# Altium schematic sheet Microcontroller.SchDoc
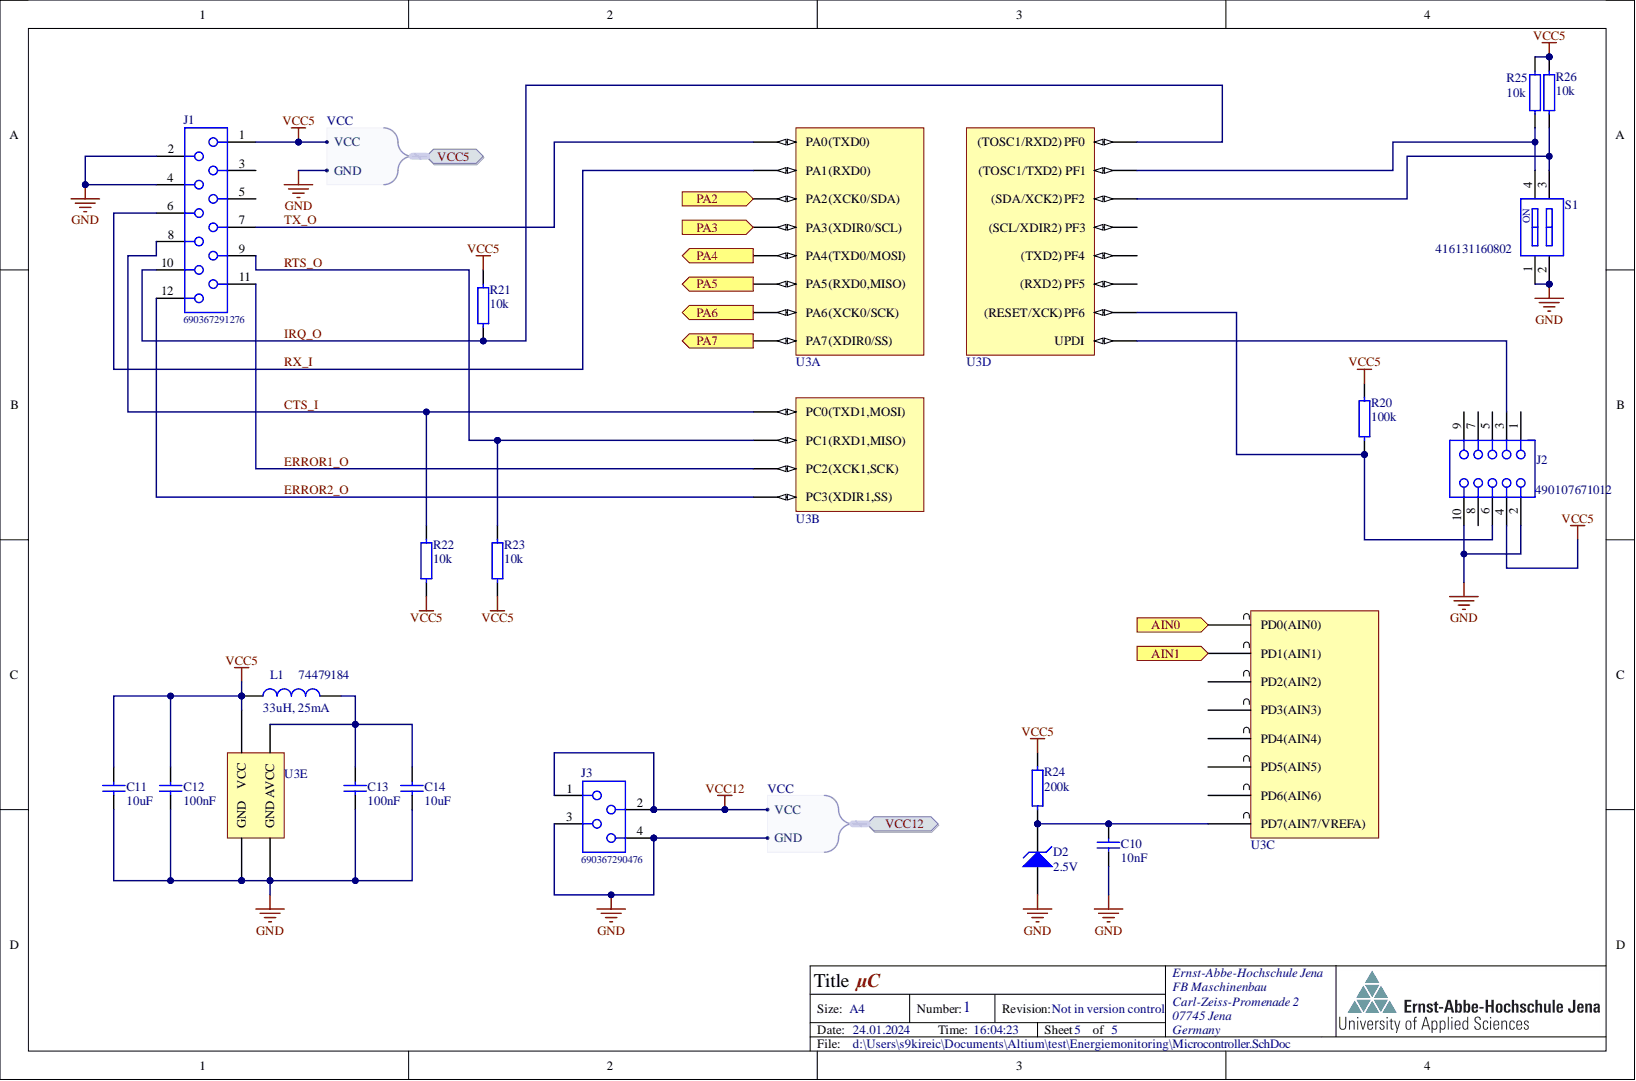
[diagram p0: Microcontroller.SchDoc]

## Parts
C10  10nF  [SMD-0805]
C11  10uF  [SMD-0805]
C12  100nF  [SMD-0805]
C13  100nF  [SMD-0805]
C14  10uF  [SMD-0805]
D2  value 2.5V  [TO92-3]  (hidden comment: Micropower Voltage Reference Diode)
J1  690367291276  [690367291276]
J2  490107671012  [490107671012_Permanent Application]
J3  690367290476  [690367290476]
L1  33uH, 25mA  Rated Current: 25mA  [WE-MI_1206]
R20  100k  [R-SMD-0805]
R21  10k  [R-SMD-0805]
R22  10k  [R-SMD-0805]
R23  10k  [R-SMD-0805]
R24  200k  [R-SMD-0805]
R25  10k  [R-SMD-0805]
R26  10k  [R-SMD-0805]
S1  416131160802  [416131160802]
U3  ATmega808-AUR  [32-Pin TQFP]  (value not drawn)  (parts A,B,C,D,E)

## Ports
AIN0, AIN1, PA2, PA3, PA4, PA5, PA6, PA7, VCC5, VCC5.GND, VCC5.VCC, VCC12, VCC12.GND, VCC12.VCC

## Nets
AIN0: U3.10(PD0_(AIN0)) ; port AIN0
AIN1: U3.11(PD1_(AIN1)) ; port AIN1
CTS_I: J1.8 R22.2 U3.6(PC0_(TXD1,MOSI))
ERROR1_O: J1.11 U3.8(PC2_(XCK1,SCK))
ERROR2_O: J1.12 U3.9(PC3_(XDIR1,SS))
GND: C10.1 C11.2 C12.2 C13.2 C14.2 D2.1 J1.2 J1.4 J2.2 J2.10 J3.3 J3.4 S1.1 S1.2 U3.19(GND) U3.29(GND) ; port VCC12, port VCC5
IRQ_O: J1.10 R21.2 U3.20((TOSC1/RXD2)_PF0)
PA2: U3.32(PA2_(XCK0/SDA)) ; port PA2
PA3: U3.1(PA3_(XDIR0/SCL)) ; port PA3
PA4: U3.2(PA4_(TXD0/MOSI)) ; port PA4
PA5: U3.3(PA5_(RXD0,MISO)) ; port PA5
PA6: U3.4(PA6_(XCK0/SCK)) ; port PA6
PA7: U3.5(PA7_(XDIR0/SS)) ; port PA7
RTS_O: J1.9 R23.2 U3.7(PC1_(RXD1,MISO))
RX_I: J1.6 U3.31(PA1_(RXD0))
TX_O: J1.7 U3.30(PA0_(TXD0))
VCC5: C11.1 C12.1 J1.1 J2.4 L1.1 R20.2 R21.1 R22.1 R23.1 R24.2 R25.1 R26.1 U3.28(VCC) ; port VCC5
VCC12: J3.1 J3.2 ; port VCC12
NetC11_1: C13.1 C14.1 L1.2 U3.18(AVCC)
NetC13_2: C10.2 D2.2 R24.1 U3.17(PD7_(AIN7/VREFA))
NetJ1_3: J1.3
NetJ1_5: J1.5
NetJ2_1: J2.1
NetJ2_3: J2.3 U3.27(UPDI)
NetJ2_5: J2.5
NetJ2_6: J2.6 R20.1 U3.26((RESET/XCK)_PF6)
NetJ2_7: J2.7
NetJ2_8: J2.8
NetJ2_9: J2.9
NetR30_2: R25.2 S1.4 U3.21((TOSC1/TXD2)_PF1)
NetR31_2: R26.2 S1.3 U3.22((SDA/XCK2)_PF2)
NetU3_12: U3.12(PD2_(AIN2))
NetU3_13: U3.13(PD3_(AIN3))
NetU3_14: U3.14(PD4_(AIN4))
NetU3_15: U3.15(PD5_(AIN5))
NetU3_16: U3.16(PD6_(AIN6))
NetU3_23: U3.23((SCL/XDIR2)_PF3)
NetU3_24: U3.24((TXD2)_PF4)
NetU3_25: U3.25((RXD2)_PF5)

## Library data of the parts
C10-C14 = C: Kondensator; Component Kind: Standard; Library Name: SMD.IntLib
  C10: Value: 10nF
  C11 C14: Value: 10uF
  C12 C13: Value: 100nF
D2 = LM285-2.5-N: • ±20 mV (±0.8%) max. Initial Tolerance (A Grade)  • Operating Current of 20 μA to 20 mA  • 0.6Ω Dynamic Impedance (A Grade)  • Low Temperature Coefficient  • Low Voltage Reference—2.5V  • 1.2V Device and Adjustable Device Also Available—LM185-1.2 Series and LM185 Series, respectively; Component Kind: Standard; ComponentLink1Description: Datasheet; ComponentLink1URL: https://www.ti.com/lit/gpn/lm285-2.5-n; ComponentLink2Description: Link; ComponentLink2URL: https://www.ti.com/product/LM285-2.5-N?keyMatch=LM285-2.5-N&tisearch=search-everything&usecase=GPN-ALT; Library Name: TI-Ref.IntLib; Supplier 1: Digikey; Supplier 2: Mouser; Supplier Part Number 1: LM285BXZ-2.5/NOPB-ND; Supplier Part Number 2: 926-LM285BXZ25NOPB
J1 = 690367291276: WR-MM Mini Module Connector, Female, SMT, with Latch & Polarization, Straight, 12p; Manufacturer: Wurth Elektronik; Contact Resistance: 10mOhm; Insulation Resistance: 1000MOhm; Pin Number: 12; Pitch: 2.54mm; Rated Current: 1.5A; Withstanding Voltage: 500VAC; Working Voltage: 100VAC; Component Kind: Standard; ComponentLink1Description: Manufacturer; ComponentLink1URL: http://www.we-online.de; ComponentLink2Description: Datasheet; ComponentLink2URL: https://www.we-online.com/catalog/datasheet/690367291276.pdf; Gender: Female; Length: 19.84mm; Library Name: 690367291076_Altium_WR-MM_rev20a.IntLib; Manufacturer Part Number: 690367291276; Mounting Technology: SMT; Operating Temperature: -40 to +105degC; Packaging: Tape and Reel; Published Date: May 2020; Type: Straight
J2 = 490107671012: WR-WST REDFIT IDC SKEDD, pitch 2.54mm, 10p; Manufacturer: Wurth Elektronik; Contact Resistance: 10mΩ; Gender: Transition; Rated Current: 1A; Working Voltage: 100VAC; Category: Wire-to-Board Connectors; Component Kind: Standard; ComponentLink1Description: Website Link; ComponentLink1URL: https://www.we-online.com/redexpert/article/490107671012?ad; ComponentLink2Description: Datasheet Link; ComponentLink2URL: https://www.we-online.com/redexpert/spec/490107671012?ad; Library Name: Altium_WR-WST (rev22a).IntLib; Manufacturer Part Number: 490107671012; Match Code: WR-WST; Mount: Press Fit; Number of Pins: 10; Operating Temperature Max: 105°C; Operating Temperature Min: -25°C; Packaging: Tube; Pitch: 2.54mm
J3 = 690367290476: WR-MM Mini Module Connector, Female, SMT, with Latch & Polarization, Straight, 4p; Manufacturer: Wurth Elektronik; Contact Resistance: 10mOhm; Insulation Resistance: 1000MOhm; Pin Number: 4; Pitch: 2.54mm; Rated Current: 1.5A; Withstanding Voltage: 500VAC; Working Voltage: 100VAC; Component Kind: Standard; ComponentLink1Description: Manufacturer; ComponentLink1URL: http://www.we-online.de; ComponentLink2Description: Datasheet; ComponentLink2URL: https://www.we-online.com/catalog/datasheet/690367290476.pdf; Gender: Female; Length: 9.68mm; Library Name: 690367291076_Altium_WR-MM_rev20a.IntLib; Manufacturer Part Number: 690367290476; Mounting Technology: SMT; Operating Temperature: -40 to +105degC; Packaging: Tape and Reel; Published Date: May 2020; Type: Straight
L1 = 74479184: WE-MI SMT Multilayer Inductor, size 1206, 33uH, 25mA; Manufacturer: Wurth Elektronik; DC Resistance max.: 0.9Ohm; DC Resistance typ.: 0.65Ohm; Q-Factor: 35; Component Kind: Standard; ComponentLink1Description: Manufacturer; ComponentLink1URL: http://www.we-online.de; ComponentLink2Description: Datasheet; ComponentLink2URL: https://www.we-online.com/catalog/datasheet/74479184.pdf; Inductance: 33uH; Manufacturer Part Number: 74479184; Mounting Technology: SMT; Operating Temperature: -55 to +125degC; Packaging: Tape and Reel; Published Date: April 2020; Size: 1206; Library Name: Altium_WE_eiSos_WE-MI.IntLib
R20-R26 = R: Widerstand; Component Kind: Standard; Library Name: SMD.IntLib
  R20: Value: 100k
  R21-R23 R25 R26: Value: 10k
  R24: Value: 200k
S1 = 416131160802: WS-DISV Small Compact SMD Dip Switch, Flat Actuator with Top Tape, pitch 1.27mm, 2p; Actuator Type: Flat Type; Circuit: SPST-NO; Operation Force: 500g; Pole Number: 2; Rated Current Non-Switching: 100mA; Rated Current Switching: 25mA; Rated Voltage Non-Switching: 50VDC; Rated Voltage Switching: 24VDC; Component Kind: Standard; ComponentLink1Description: Manufacturer; ComponentLink1URL: http://www.we-online.de; ComponentLink2Description: Datasheet; ComponentLink2URL: https://katalog.we-online.com/em/datasheet/416131160802.pdf; Contact Material: Copper Alloy; Contact Plating: Gold; Library Name: Altium_WS-DISV (rev19b).IntLib; Mounting Technology: SMT; Operating Temperature: -40 to +85degC; Packaging: Tape and Reel; Part Number: 416131160802; Pitch: 1.27mm; Published Date: September 2019; Publisher: Wurth Elektronik
U3 = ATmega808-AUR: The ATmega808 microcontrollers of the megaAVR® 0-series are using the AVR® processor with  hardware multiplier, running at up to 20 MHz, with a wide range of Flash sizes up to 8 KB, up to 1 KB of SRAM, and  256 bytes of EEPROM in 32-pin package. The series uses the latest technologies from Microchip  with a flexible and low-power architecture including Event System and SleepWalking, accurate analog features and  advanced peripherals; Ram size: 1KB; Rom size: 8KB; Temp: -40°C to +85°C; Component Kind: Standard; ComponentLink1Description: web adress; ComponentLink1URL: https://www.microchip.com/wwwproducts/en/ATMEGA808; ComponentLink2Description: Datasheet; ComponentLink2URL: http://ww1.microchip.com/downloads/en/DeviceDoc/ATmega808-809-1608-1609-Data-Sheet-DS40002172A.pdf; Library Name: ATmega.IntLib; Supplier 1: Digi-Key; Supplier 2: Mouser; Supplier 3: Avnet; Supplier Part Number 1: 150-ATMEGA808-AURCT-ND; Supplier Part Number 2: 556-ATMEGA808-AUR; Supplier Part Number 3: ATMEGA808-AUR
